# Altium schematic sheet MCU_Connection.SchDoc
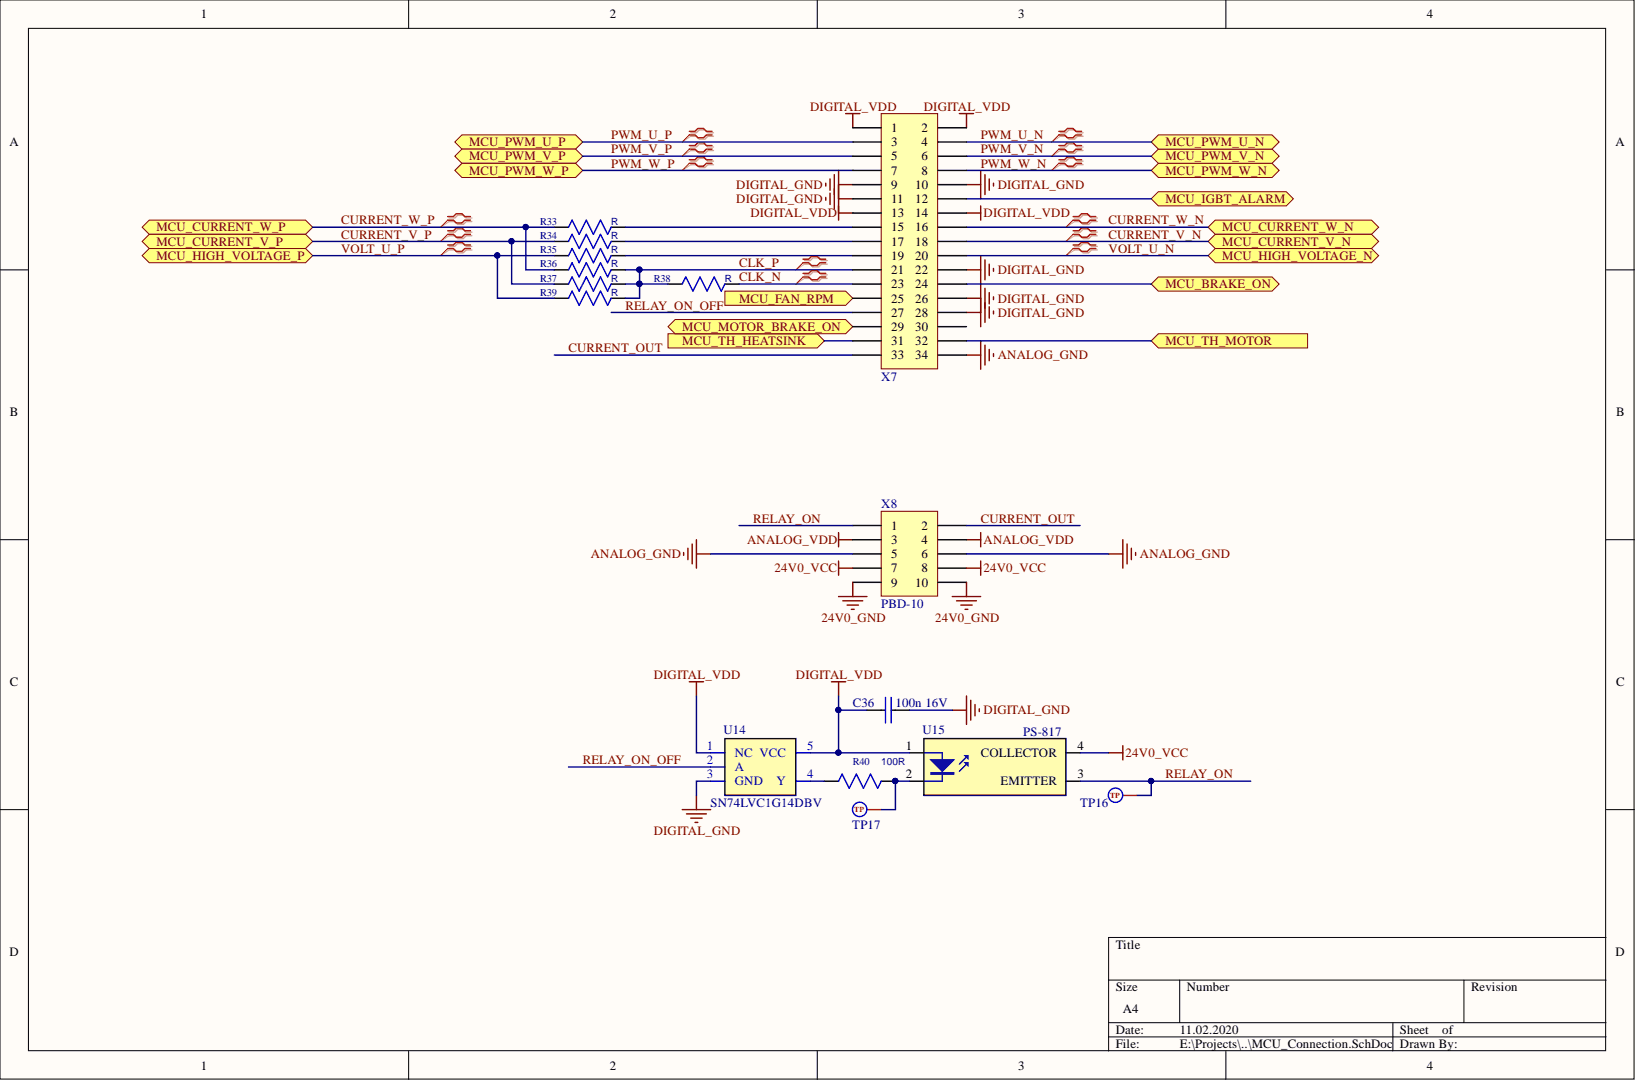
[diagram p0: MCU_Connection.SchDoc]

## Parts
C36  100n 16V  [C_0805]
R33  R  [R_0805]
R34  R  [R_0805]
R35  R  [R_0805]
R36  R  [R_0805]
R37  R  [R_0805]
R38  R  [R_0805]
R39  R  [R_0805]
R40  100R  [R_0805]
TP16  TEST_POINT  [TEST POINT D1.5]  (value not drawn)
TP17  TEST_POINT  [TEST POINT D1.5]  (value not drawn)
U14  SN74LVC1G14DBV  [SOT23-5]
U15  PS-817  [PS817_SO]
X7  PBD-34  [PBD-34-3D]  (value not drawn)
X8  PBD-10  [PBD-10-3D]

## Ports
MCU_BRAKE_ON, MCU_CURRENT_V_N, MCU_CURRENT_V_P, MCU_CURRENT_W_N, MCU_CURRENT_W_P, MCU_FAN_RPM, MCU_HIGH_VOLTAGE_N, MCU_HIGH_VOLTAGE_P, MCU_IGBT_ALARM, MCU_MOTOR_BRAKE_ON, MCU_PWM_U_N, MCU_PWM_U_P, MCU_PWM_V_N, MCU_PWM_V_P, MCU_PWM_W_N, MCU_PWM_W_P, MCU_TH_HEATSINK, MCU_TH_MOTOR

## Nets
24V0_GND: X8.9 X8.10
24V0_VCC: U15.4(COLLECTOR) X8.7 X8.8
ANALOG_GND: X7.34 X8.5 X8.6
ANALOG_VDD: X8.3 X8.4
DIGITAL_GND: C36.2 U14.3(GND) X7.9 X7.10 X7.11 X7.22 X7.26 X7.28
DIGITAL_VDD: C36.1 U14.1(NC) U14.5(VCC) U15.1 X7.1 X7.2 X7.13 X7.14
CLK_N: R38.1 X7.23
CLK_P: R36.1 R37.1 R38.2 R39.1 X7.21
CURRENT_OUT: X7.33 X8.2
CURRENT_V_N: X7.18 ; port MCU_CURRENT_V_N
CURRENT_V_P: R34.2 R37.2 ; port MCU_CURRENT_V_P
CURRENT_W_N: X7.16 ; port MCU_CURRENT_W_N
CURRENT_W_P: R33.2 R36.2 ; port MCU_CURRENT_W_P
MCU_BRAKE_ON: X7.24 ; port MCU_BRAKE_ON
MCU_FAN_RPM: X7.25 ; port MCU_FAN_RPM
MCU_IGBT_ALARM: X7.12 ; port MCU_IGBT_ALARM
MCU_MOTOR_BRAKE_ON: X7.29 ; port MCU_MOTOR_BRAKE_ON
MCU_TH_HEATSINK: X7.31 ; port MCU_TH_HEATSINK
MCU_TH_MOTOR: X7.32 ; port MCU_TH_MOTOR
PWM_U_N: X7.4 ; port MCU_PWM_U_N
PWM_U_P: X7.3 ; port MCU_PWM_U_P
PWM_V_N: X7.6 ; port MCU_PWM_V_N
PWM_V_P: X7.5 ; port MCU_PWM_V_P
PWM_W_N: X7.8 ; port MCU_PWM_W_N
PWM_W_P: X7.7 ; port MCU_PWM_W_P
RELAY_ON: TP16.1 U15.3(EMITTER) X8.1
RELAY_ON_OFF: U14.2(A) X7.27
VOLT_U_N: X7.20 ; port MCU_HIGH_VOLTAGE_N
VOLT_U_P: R35.2 R39.2 ; port MCU_HIGH_VOLTAGE_P
NetR33_1: R33.1 X7.15
NetR34_1: R34.1 X7.17
NetR35_1: R35.1 X7.19
NetR40_1: R40.1 U14.4(Y)
NetR40_2: R40.2 TP17.1 U15.2

Not connected: X7 30

## Library data of the parts
C36 = Cap NP: CERAMIC CAPACITOR; Component Kind: Standard; Library Name: Capacitors.SchLib; Value: 100n 16V
R33-R40 = R0805: Resistor; Component Kind: Standard; Library Name: Resistors.SchLib
  R33-R39: Value: R
  R40: Value: 100R
TP16 TP17 = TEST_POINT: Signal Test Point; Component Kind: Standard; Library Name: Discrete.SchLib
U14 = SN74LVC1G14DBV: Syngle Inverter Schmitt Gate SOT23-5; Component Kind: Standard; Value: SN74LVC1G14DBV; Library Name: Gates.SchLib
U15 = PS-817: DIP and SMD Package; Component Kind: Standard; Library Name: Isolator.SchLib
X7 = PBD-34: Header, 17-Pin, Dual row; System: Connector; Component Kind: Standard; LatestRevisionDate: 17-Jul-2002; LatestRevisionNote: Re-released for DXP Platform.; Library Name: Connectors.SchLib; Publisher: Altium Limited
X8 = PBD-10: Header, 5-Pin, Dual row; System: Connector; Component Kind: Standard; Library Name: Connectors.SchLib
